# Altium schematic sheet 419-005-01-02_PG 15 - BOARD_POWER.SchDoc("419-005-01-02_PG 15 - BOARD_POWER")
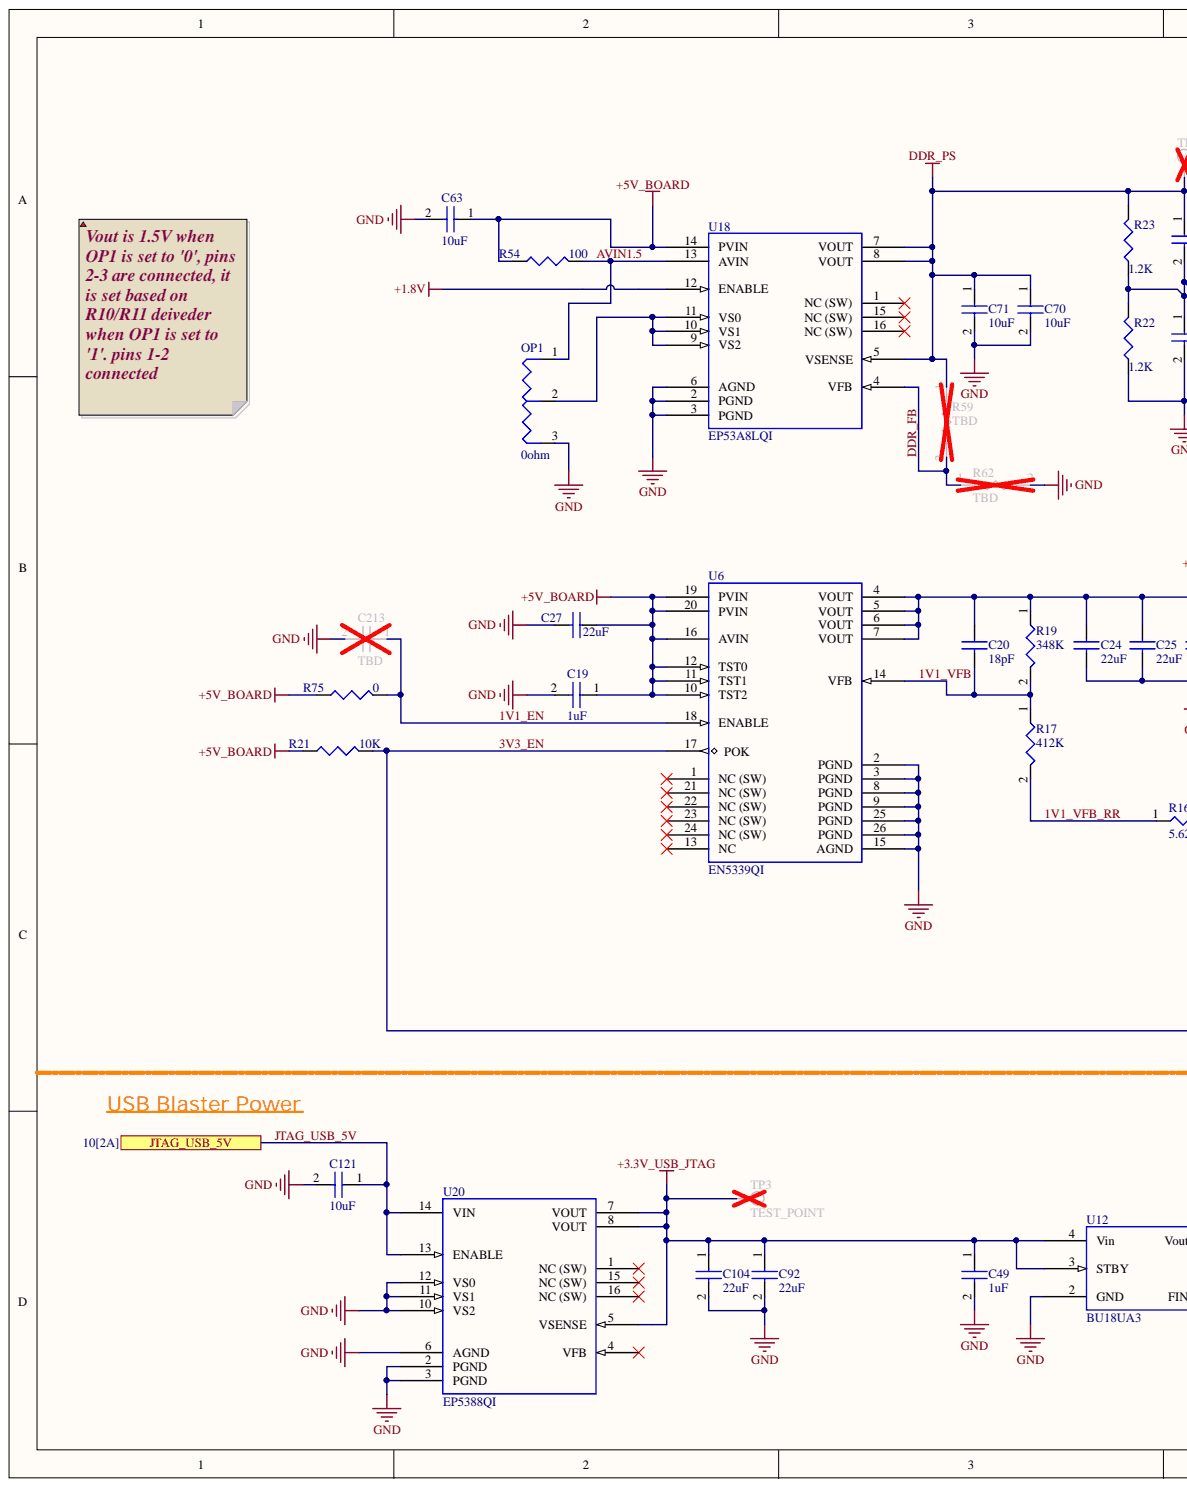
[diagram p0: 419-005-01-02_PG 15 - BOARD_POWER.SchDoc, left]
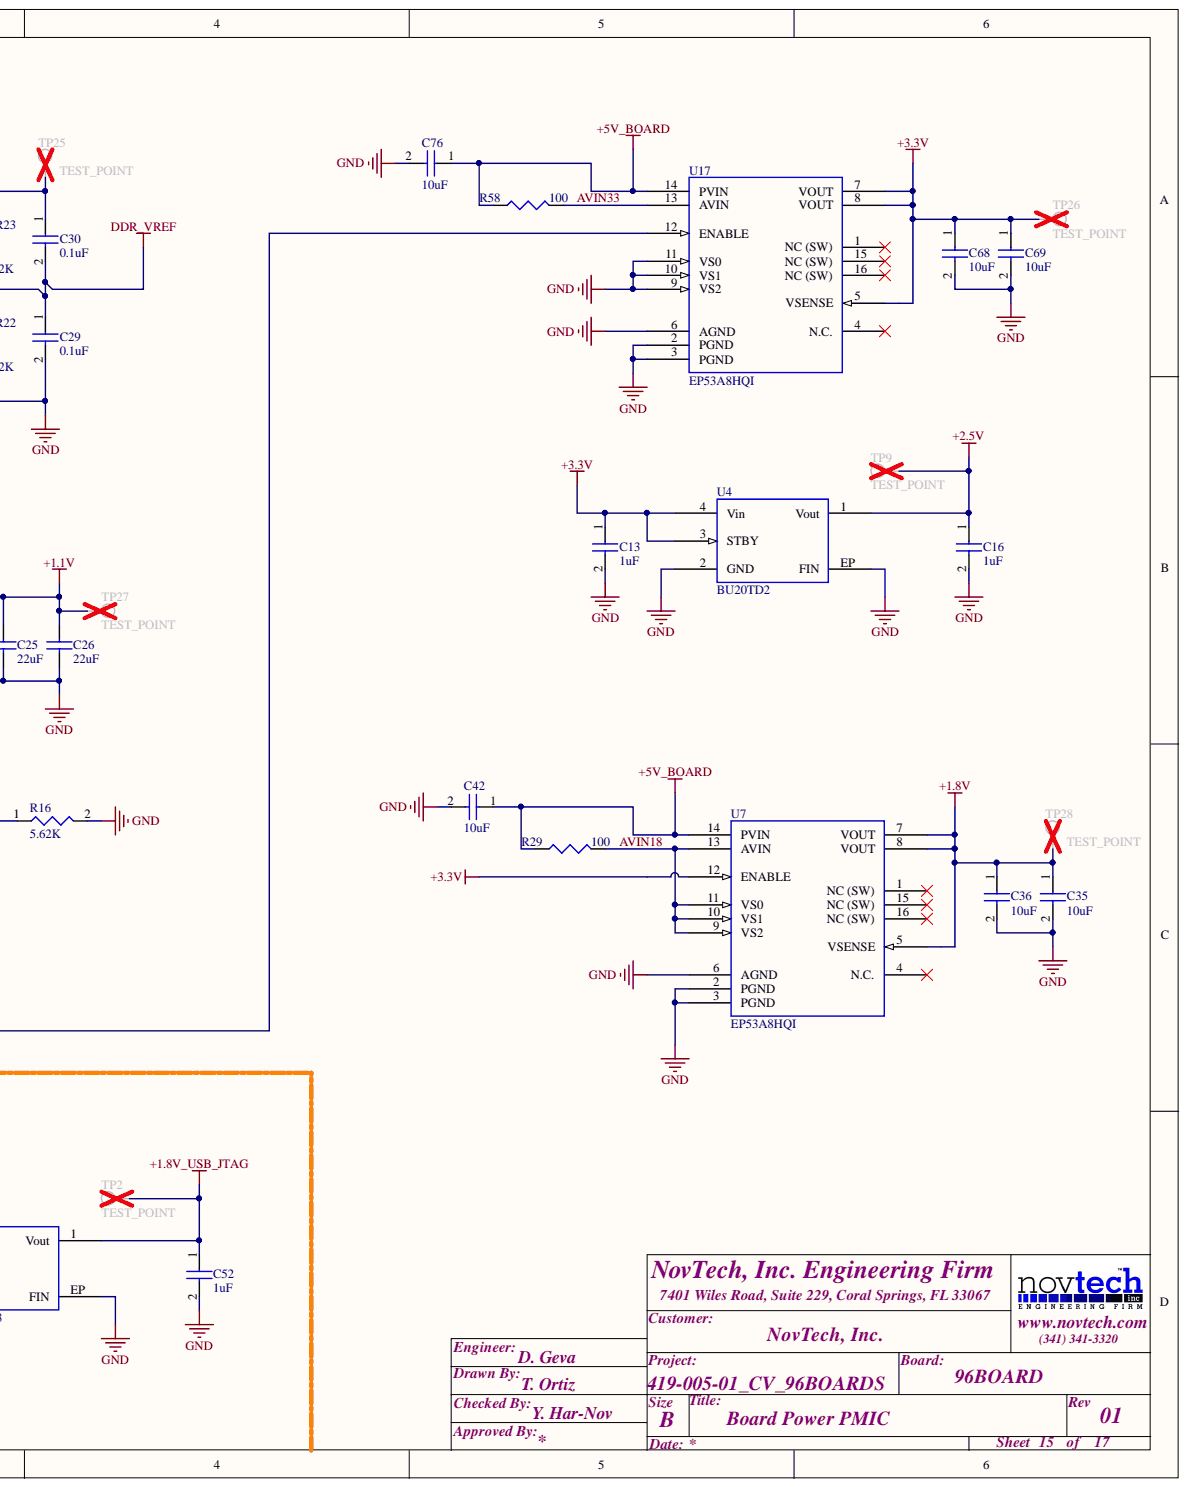
[diagram p1: 419-005-01-02_PG 15 - BOARD_POWER.SchDoc, right]

## Parts
C13  1uF  [0201_C]
C16  1uF  [0201_C]
C19  1uF  [0201_C]
C20  18pF  [0201_C]
C24  22uF  [0805_C]
C25  22uF  [0805_C]
C26  22uF  [0805_C]
C27  22uF  [0805_C]
C29  0.1uF  [0201_C]
C30  0.1uF  [0201_C]
C35  10uF  [0805_C]
C36  10uF  [0805_C]
C42  10uF  [0805_C]
C49  1uF  [0201_C]
C52  1uF  [0201_C]
C63  10uF  [0805_C]
C68  10uF  [0805_C]
C69  10uF  [0805_C]
C70  10uF  [0805_C]
C71  10uF  [0805_C]
C76  10uF  [0805_C]
C92  22uF  [0805_C]
C104  22uF  [0805_C]
C121  10uF  [0603_C]
C213  TBD  [0402_C]
OP1  0ohm  [OPT_RES_0402]
R16  5.62K  [0402_R]
R17  412K  [0402_R]
R19  348K  [0402_R]
R21  10K  [0201_R]
R22  1.2K  [0201_R]
R23  1.2K  [0201_R]
R29  100  [0201_R]
R54  100  [0201_R]
R58  100  [0201_R]
R59  TBD  [0402_R]
R62  TBD  [0402_R]
R75  0  [0201_R]
TP2  TEST_POINT  [TEST_POINT_SMT]
TP3  TEST_POINT  [TEST_POINT_SMT]
TP9  TEST_POINT  [TEST_POINT_SMT]
TP25  TEST_POINT  [TEST_POINT_SMT]
TP26  TEST_POINT  [TEST_POINT_SMT]
TP27  TEST_POINT  [TEST_POINT_SMT]
TP28  TEST_POINT  [TEST_POINT_SMT]
U4  BU20TD2  [SSON004X1010]
U6  EN5339QI  [EN5399]
U7  EP53A8HQI  [EP53A8]
U12  BU18UA3  [SSON004X1010]
U17  EP53A8HQI  [EP53A8]
U18  EP53A8LQI  [EP53A8]
U20  EP5388QI  [EP53A8]

## Ports
JTAG_USB_5V

## Nets
+1.1V: C20.1 C24.1 C25.1 C26.1 R19.1 TP27.1 U6.4(VOUT) U6.5(VOUT) U6.6(VOUT) U6.7(VOUT)
+1.8V: C35.1 C36.1 TP28.1 U7.5(VSENSE) U7.7(VOUT) U7.8(VOUT) U18.12(ENABLE)
+1.8V_USB_JTAG: C52.1 TP2.1 U12.1(Vout)
+2.5V: C16.1 TP9.1 U4.1(Vout)
+3.3V: C13.1 C68.1 C69.1 TP26.1 U4.3(STBY) U4.4(Vin) U7.12(ENABLE) U17.5(VSENSE) U17.7(VOUT) U17.8(VOUT)
+3.3V_USB_JTAG: C49.1 C92.1 C104.1 TP3.1 U12.3(STBY) U12.4(Vin) U20.5(VSENSE) U20.7(VOUT) U20.8(VOUT)
+5V_BOARD: C19.1 C27.1 C42.1 C63.1 C76.1 R21.1 R29.1 R54.1 R58.1 R75.1 U6.10(TST2) U6.11(TST1) U6.12(TST0) U6.16(AVIN) U6.19(PVIN) U6.20(PVIN) U7.14(PVIN) U17.14(PVIN) U18.14(PVIN)
DDR_PS: C30.1 C70.1 C71.1 R23.2 R59.1 TP25.1 U18.5(VSENSE) U18.7(VOUT) U18.8(VOUT)
DDR_VREF: C29.1 C30.2 R22.2 R23.1
GND: C13.2 C16.2 C19.2 C24.2 C25.2 C26.2 C27.2 C29.2 C35.2 C36.2 C42.2 C49.2 C52.2 C63.2 C68.2 C69.2 C70.2 C71.2 C76.2 C92.2 C104.2 C121.2 C213.2 OP1.3 R16.2 R22.1 R62.2 U4.2(GND) U4.EP(FIN) U6.2(PGND) U6.3(PGND) U6.8(PGND) U6.9(PGND) U6.15(AGND) U6.25(PGND) U6.26(PGND) U7.2(PGND) U7.3(PGND) U7.6(AGND) U12.2(GND) U12.EP(FIN) U17.2(PGND) U17.3(PGND) U17.6(AGND) U17.9(VS2) U17.10(VS1) U17.11(VS0) U18.2(PGND) U18.3(PGND) U18.6(AGND) U20.2(PGND) U20.3(PGND) U20.6(AGND) U20.10(VS2) U20.11(VS1) U20.12(VS0)
1V1_EN: C213.1 R75.2 U6.18(ENABLE)
1V1_VFB: C20.2 R17.1 R19.2 U6.14(VFB)
1V1_VFB_RR: R16.1 R17.2
3V3_EN: R21.2 U6.17(POK) U17.12(ENABLE)
AVIN1.5: OP1.1 R54.2 U18.13(AVIN)
AVIN18: R29.2 U7.9(VS2) U7.10(VS1) U7.11(VS0) U7.13(AVIN)
AVIN33: R58.2 U17.13(AVIN)
DDR_FB: R59.2 R62.1 U18.4(VFB)
JTAG_USB_5V: C121.1 U20.13(ENABLE) U20.14(VIN) ; port JTAG_USB_5V
NetOP1_2: OP1.2 U18.9(VS2) U18.10(VS1) U18.11(VS0)

Not connected: U6 1(NC_(SW)) 13(NC) 21(NC_(SW)) 22(NC_(SW)) 23(NC_(SW)) 24(NC_(SW)); U7 1(NC_(SW)) 4(N.C.) 15(NC_(SW)) 16(NC_(SW)); U17 1(NC_(SW)) 4(N.C.) 15(NC_(SW)) 16(NC_(SW)); U18 1(NC_(SW)) 15(NC_(SW)) 16(NC_(SW)); U20 1(NC_(SW)) 4(VFB) 15(NC_(SW)) 16(NC_(SW))

## Library data of the parts
C13 C16 C19 C20 C29 C30 C49 C52 = CAP_0201: Capasitor 0201 footprint; Component Kind: Standard; Library Name: PASSIVES.SchLib
C24-C27 C35 C36 C42 C63 C68-C71 C76 C92 C104 = CAP_0805: Capasitor 0805 footprint; Bottom: 10; Right: 20; Component Kind: Standard; Library Name: PASSIVES.SchLib
C121 = CAP_0603: Capasitor 0603 footprint; Bottom: 10; Right: 20; Component Kind: Standard; Library Name: PASSIVES.SchLib
C213 = CAP_0402: Capasitor 0402 footprint; Bottom: 10; Right: 20; Component Kind: Standard; Library Name: PASSIVES.SchLib
OP1 = OPT_RES: Resistor; Component Kind: Standard; Library Name: PASSIVES.SchLib
R16 R17 R19 R59 R62 = RESISOTR 0402: Resistor 0402 footprint; Component Kind: Standard; Library Name: PASSIVES.SchLib
R21 = RESISOTR 0201: Resistor 0201 footprint; PART: ERJ-1GEF1002C; MFG: Panasonic; Component Kind: Standard; Digikey: P10.0KABCT-ND; Library Name: PASSIVES.SchLib; RoHS: Yes; TYPE: SMT
R22 R23 = RESISOTR 0201: Resistor 0201 footprint; PART: ERJ-1GEF1201C; MFG: Panasonic; Component Kind: Standard; Digikey: P1.20KABCT-ND; Library Name: PASSIVES.SchLib; RoHS: Yes; TYPE: SMT
R29 R54 R58 = RESISOTR 0201: Resistor 0201 footprint; PART: RMCF0201FT100R; MFG: Stackpole; TEMP: -55°C t0 +125°C; Component Kind: Standard; DigiKey: RMCF0201FT100RCT-ND; Library Name: PASSIVES.SchLib; RoHS: Yes; TYPE: SMT
R75 = RESISOTR 0201: Resistor 0201 footprint; MFG: Panasonic; Component Kind: Standard; Library Name: PASSIVES.SchLib; RoHS: Yes; TYPE: SMT
TP2 TP3 TP9 TP25-TP28 = TEST_POINT: PCB Test Point; Component Kind: Standard; Library Name: PASSIVES.SchLib
U4 = MIC5365: PART: BU25UA3WNVX-TL; MARKING: G; MFG: ROHM; TEMP: -40°C to +125°C; Component Kind: Standard; DigiKey: BU25UA3WNVX-TLCT-ND; Library Name: IC_SchLib.SCHLIB; RoHS: Yes; TYPE: SMT
U6 = EN5339QI: 3A PowerSoC Sunc Buck DC-DC Convertor with integrated Inductor; MFG: Altera; TEMP: -40°C to +85°C; Component Kind: Standard; Library Name: IC_SchLib.SCHLIB; PART: EN5339QI; RoHS: Yes; TYPE: SMT
U7 U17 U18 = EP53A8x: 1A PowerSoC Sunc Back Regulator with Intg. Indc.; MFG: Altera; TEMP: -40°C to +85°C; Component Kind: Standard; Library Name: IC_SchLib.SCHLIB; RoHS: Yes; Type: SMT
  U7 U17: PART: EP53A8HQI
  U18: PART: EP53A8LQI
U12 = MIC5365: PART: BU18UA3WNVX-TL; MARKING: G; MFG: ROHM; TEMP: -40°C to +125°C; Component Kind: Standard; DigiKey: BU18UA3WNVX-TLCT-ND; Library Name: IC_SchLib.SCHLIB; RoHS: Yes; TYPE: SMT
U20 = EP5388QI: 800mA PowerSoC Sunc Back Regulator with Intg. Indc.; MFG: Altera; TEMP: -40°C to +85°C; Component Kind: Standard; Library Name: IC_SchLib.SCHLIB; PART: EP5388QI; RoHS: Yes; Type: SMT
